# Altium schematic sheet usb-debug.SchDoc(usb-debug)
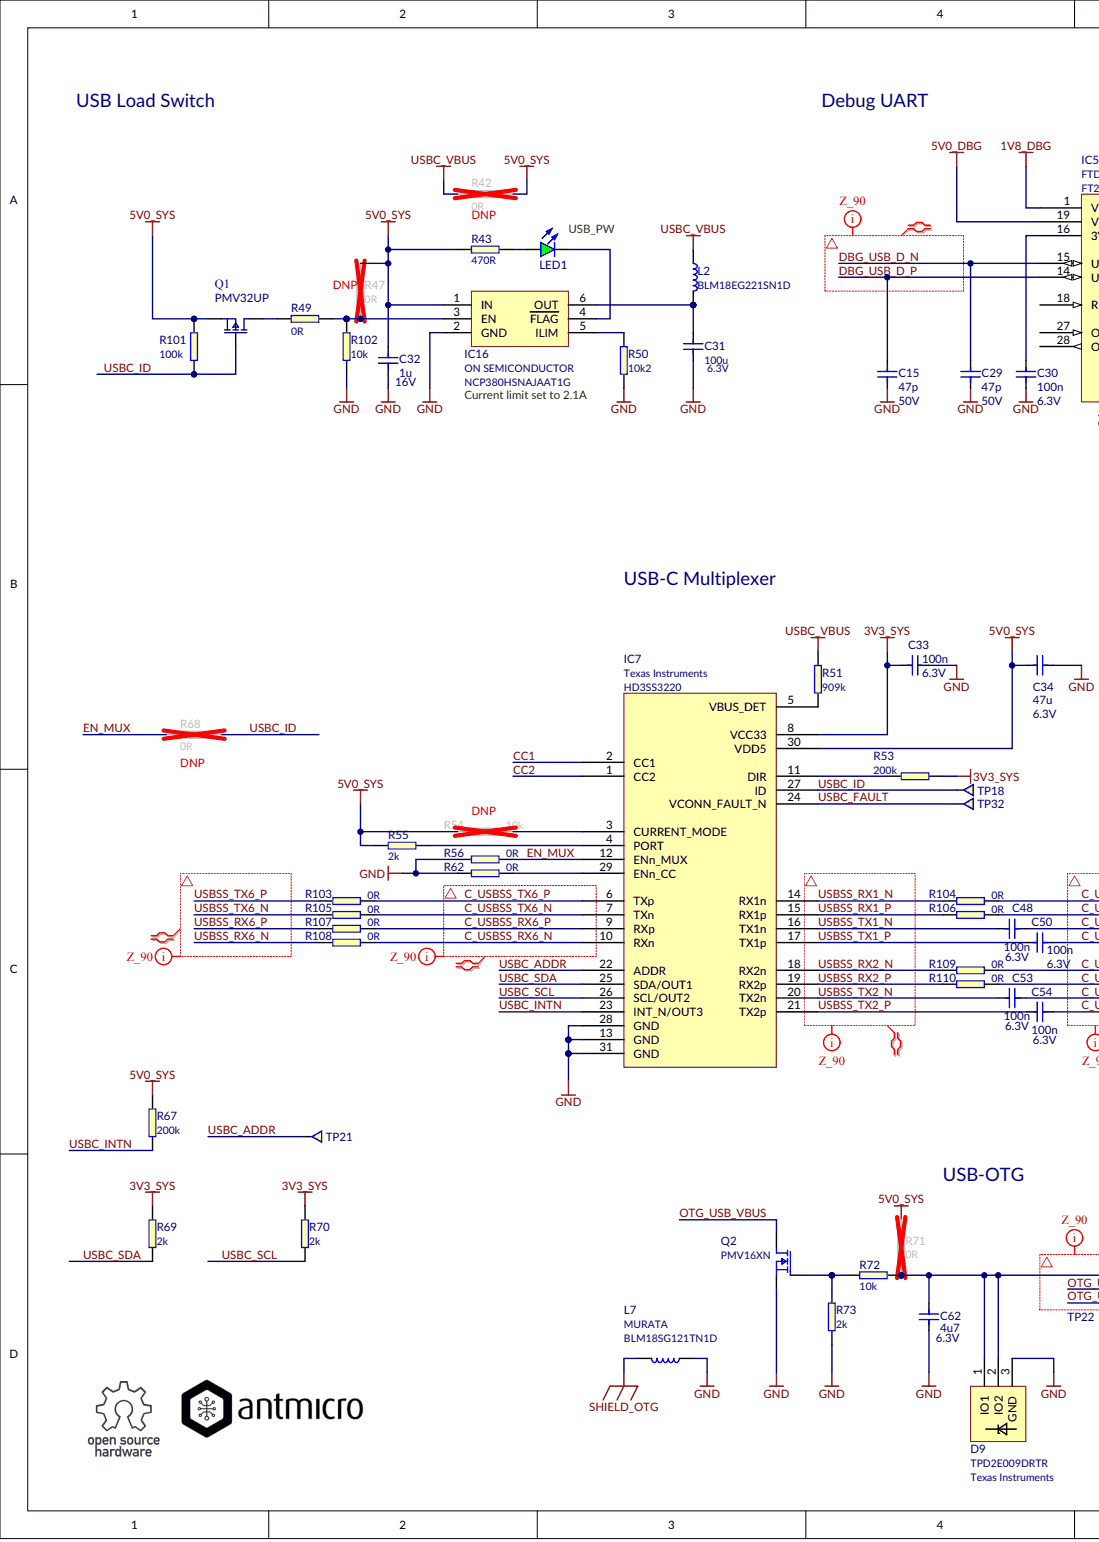
[diagram p0: usb-debug.SchDoc, left]
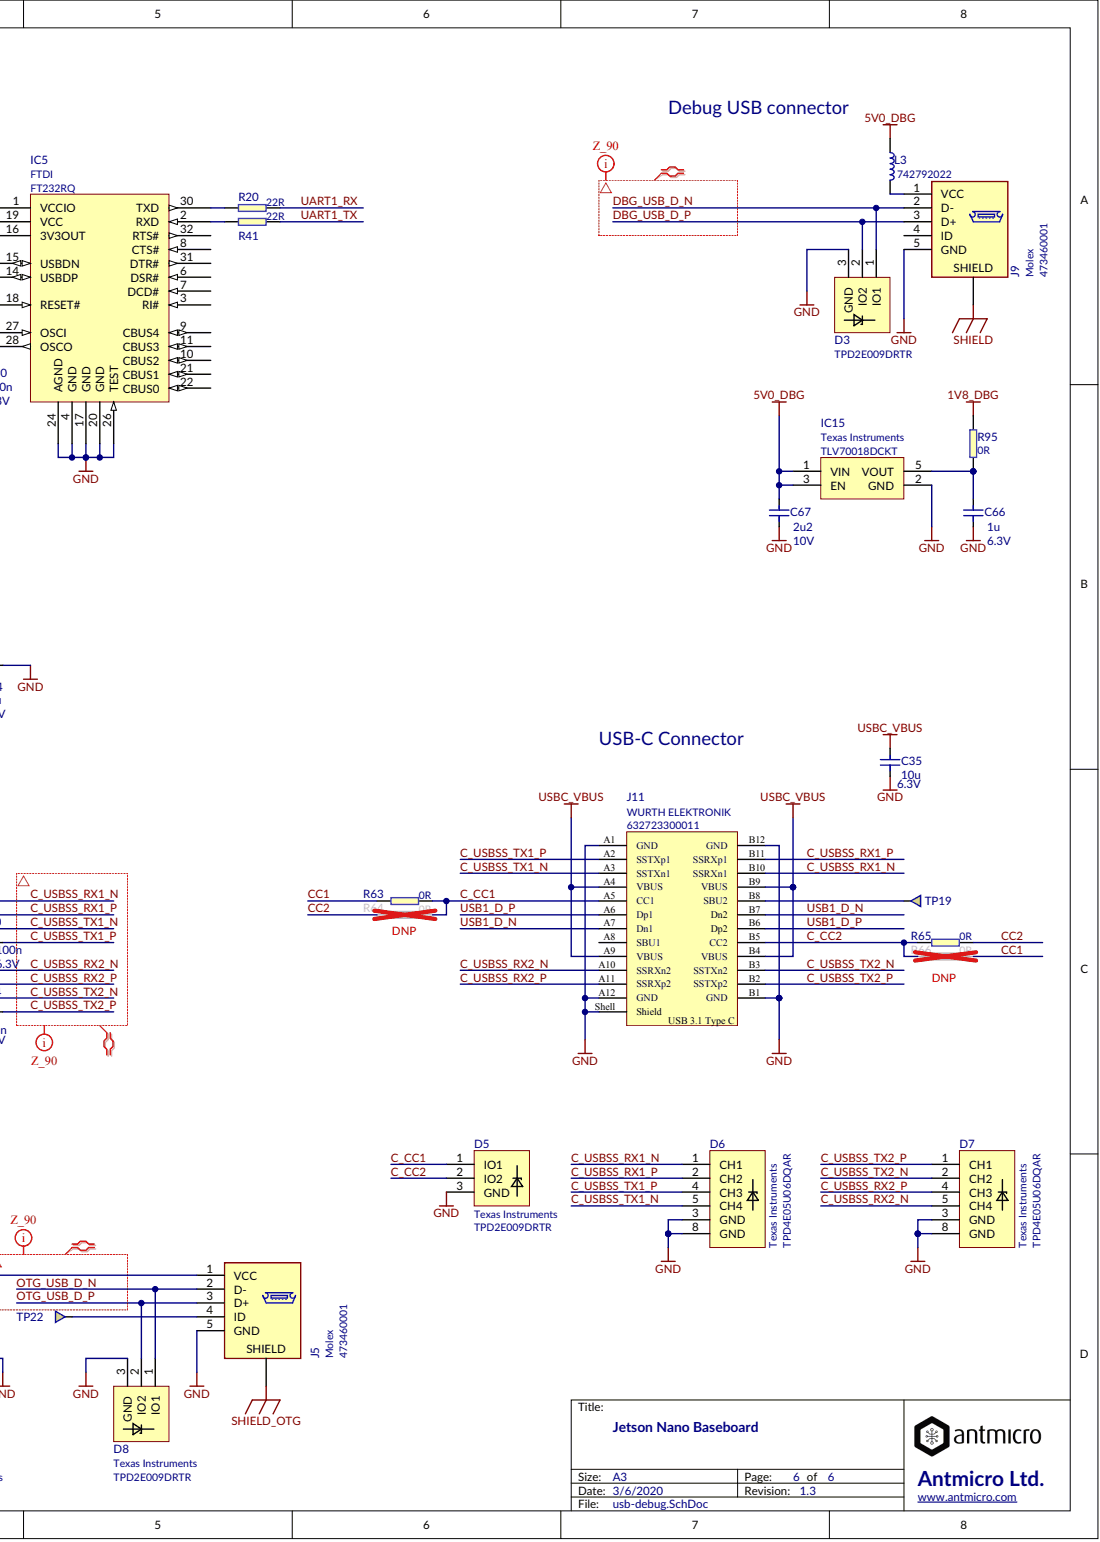
[diagram p1: usb-debug.SchDoc, right]

## Parts
C15  Voltage: 50V  (hidden comment: 47p)
C29  Voltage: 50V  (hidden comment: 47p)
C30  100n
C31  Voltage: 6.3V  (hidden comment: 100u)
C32  Voltage: 16V  (hidden comment: 1u)
C33  Voltage: 6.3V  (hidden comment: 100n)
C34  Voltage: 6.3V  (hidden comment: 47u)
C35  Voltage: 6.3V  (hidden comment: 10u)
C48  100n  (value not drawn)
C50  Voltage: 6.3V  (hidden comment: 100n)
C53  100n
C54  Voltage: 6.3V  (hidden comment: 100n)
C62  Voltage: 6.3V  (hidden comment: 4u7)
C66  Voltage: 6.3V  (hidden comment: 1u)
C67  Voltage: 10V  (hidden comment: 2u2)
D3  TPD2E009DRTR
D5  Manufacturer: Texas Instruments  (hidden comment: TPD2E009DRTR)
D6  Manufacturer: Texas Instruments  PartSymbol: TPD4E05U06DQAR  (hidden comment: TPD4E05U06)
D7  Manufacturer: Texas Instruments  PartSymbol: TPD4E05U06DQAR  (hidden comment: TPD4E05U06)
D8  Manufacturer: Texas Instruments  (hidden comment: TPD2E009DRTR)
D9  TPD2E009DRTR
IC5  Manufacturer: FTDI  (hidden comment: FT232RQ)
IC7  Manufacturer: Texas Instruments  (hidden comment: HD3SS3220)
IC15  Manufacturer: Texas Instruments  (hidden comment: TLV70018DCKT)
IC16  Manufacturer: ON SEMICONDUCTOR  (hidden comment: NCP380HSNAJAAT1G)
J5  Manufacturer: Molex  (hidden comment: Molex 47346-0001)
J9  Manufacturer: Molex  PartSymbol: 473460001  (hidden comment: Molex 47346-0001)
J11  Manufacturer: WURTH ELEKTRONIK  (hidden comment: 632723300011)
L2  BLM18EG221SN1D
L3  742792022
L7  Manufacturer: MURATA  (hidden comment: BLM18SG121TN1D)
LED1  KPT-1608SGC  (value not drawn)
Q1  PMV32UP
Q2  PMV16XN
R20  22R
R41  22R
R42  0R
R43  470R
R47  0R
R49  0R
R50  10k2
R51  909k
R53  200k
R54  10k
R55  2k
R56  0R
R62  0R
R63  0R
R64  0R
R65  0R
R66  0R
R67  200k
R68  0R
R69  2k
R70  2k
R71  0R
R72  10k
R73  2k
R95  0R
R101  100k
R102  10k
R103  0R
R104  0R
R105  0R
R106  0R
R107  0R
R108  0R
R109  0R
R110  0R
TP18  Testpad  (value not drawn)
TP19  Testpad  (value not drawn)
TP21  Testpad  (value not drawn)
TP22  Testpad  (value not drawn)
TP32  Testpad  (value not drawn)

## Nets
1V8_DBG: IC5.1(VCCIO) R95.2
3V3_SYS: C33.1 IC7.8(VCC33) R53.2 R69.1 R70.1
5V0_DBG: C67.2 IC5.19(VCC) IC15.1(VIN) IC15.3(EN) L3.2
5V0_SYS: C32.2 C34.2 IC7.30(VDD5) IC16.1(IN) Q1.2 R42.2 R43.2 R47.2 R54.1 R55.1 R67.2 R71.2 R101.1
GND: C15.1 C29.1 C30.1 C31.1 C32.1 C33.2 C34.1 C35.1 C62.1 C66.1 C67.1 D3.3 D5.3 D6.3(GND) D6.8(GND) D7.3(GND) D7.8(GND) D8.3 D9.3 IC5.4(GND) IC5.17(GND) IC5.20(GND) IC5.24(AGND) IC5.26(TEST) IC7.13(GND) IC7.28(GND) IC7.31(GND) IC15.2(GND) IC16.2(GND) J5.5(GND) J9.5(GND) J11.A1(GND) J11.A12(GND) J11.B1(GND) J11.B12(GND) J11.Shell(Shield) L7.2 Q2.2 R50.1 R56.1 R62.1 R73.2 R102.1
SHIELD: J9.S
SHIELD_OTG: J5.S L7.1
USBC_VBUS: C35.2 J11.A4(VBUS) J11.A9(VBUS) J11.B4(VBUS) J11.B9(VBUS) L2.2 R42.1 R51.2
C_CC1: D5.1 J11.A5(CC1) R63.2 R64.2
C_CC2: D5.2 J11.B5(CC2) R65.1 R66.1
C_USBSS_RX1_N: D6.1(CH1) J11.B10(SSRXn1) R104.2
C_USBSS_RX1_P: D6.2(CH2) J11.B11(SSRXp1) R106.2
C_USBSS_RX2_N: D7.5(CH4) J11.A10(SSRXn2) R109.2
C_USBSS_RX2_P: D7.4(CH3) J11.A11(SSRXp2) R110.2
C_USBSS_RX6_N: IC7.10(RXn) R108.2
C_USBSS_RX6_P: IC7.9(RXp) R107.2
C_USBSS_TX1_N: C48.1 D6.5(CH4) J11.A3(SSTXn1)
C_USBSS_TX1_P: C50.1 D6.4(CH3) J11.A2(SSTXp1)
C_USBSS_TX2_N: C53.1 D7.2(CH2) J11.B3(SSTXn2)
C_USBSS_TX2_P: C54.1 D7.1(CH1) J11.B2(SSTXp2)
C_USBSS_TX6_N: IC7.7(TXn) R105.2
C_USBSS_TX6_P: IC7.6(TXp) R103.2
CC1: IC7.2(CC1) R63.1 R66.2
CC2: IC7.1(CC2) R64.1 R65.2
DBG_USB_D_N: C29.2 D3.1 IC5.15(USBDN) J9.2(D-)
DBG_USB_D_P: C15.2 D3.2 IC5.14(USBDP) J9.3(D+)
EN_MUX: IC7.12(ENn_MUX) R56.2 R68.1
OTG_USB_D_N: D8.1 J5.2(D-)
OTG_USB_D_P: D8.2 J5.3(D+)
OTG_USB_VBUS: Q2.3
UART1_RX: R20.2
UART1_TX: R41.2
USB1_D_N: J11.A7(Dn1) J11.B7(Dn2)
USB1_D_P: J11.A6(Dp1) J11.B6(Dp2)
USBC_ADDR: IC7.22(ADDR) TP21.1
USBC_FAULT: IC7.24(VCONN_FAULT_N) TP32.1
USBC_ID: IC7.27(ID) Q1.1 R68.2 R101.2 TP18.1
USBC_INTN: IC7.23(INT_N/OUT3) R67.1
USBC_SCL: IC7.26(SCL/OUT2) R70.2
USBC_SDA: IC7.25(SDA/OUT1) R69.2
USBSS_RX1_N: IC7.14(RX1n) R104.1
USBSS_RX1_P: IC7.15(RX1p) R106.1
USBSS_RX2_N: IC7.18(RX2n) R109.1
USBSS_RX2_P: IC7.19(RX2p) R110.1
USBSS_RX6_N: R108.1
USBSS_RX6_P: R107.1
USBSS_TX1_N: C48.2 IC7.16(TX1n)
USBSS_TX1_P: C50.2 IC7.17(TX1p)
USBSS_TX2_N: C53.2 IC7.20(TX2n)
USBSS_TX2_P: C54.2 IC7.21(TX2p)
USBSS_TX6_N: R105.1
USBSS_TX6_P: R103.1
NetC30_2: C30.2 IC5.16(3V3OUT)
NetC31_2: C31.2 IC16.6(OUT) L2.1
NetC62_2: C62.2 D9.1 D9.2 J5.1(VCC) R71.1 R72.1
NetC66_2: C66.2 IC15.5(VOUT) R95.1
NetIC5_2: IC5.2(RXD) R41.1
NetIC5_30: IC5.30(TXD) R20.1
NetIC7_3: IC7.3(CURRENT_MODE) R54.2
NetIC7_4: IC7.4(PORT) R55.2
NetIC7_5: IC7.5(VBUS_DET) R51.1
NetIC7_11: IC7.11(DIR) R53.1
NetIC7_29: IC7.29(ENn_CC) R62.2
NetIC16_3: IC16.3(EN) R47.1 R49.2 R102.2
NetIC16_4: IC16.4(FLAG) LED1.K
NetIC16_5: IC16.5(ILIM) R50.2
NetJ5_4: J5.4(ID) TP22.1
NetJ9_1: J9.1(VCC) L3.1
NetJ11_B8: J11.B8(SBU2) TP19.1
NetLED1_A: LED1.A R43.1
NetQ1_3: Q1.3 R49.1
NetQ2_1: Q2.1 R72.2 R73.1
N00534:
N00535:
N00536:
N00537:
N00538:
N00539:
N00540:
N00541:
N00542:
N00543:
N00544:
N00545:
N00546:
N00547:

Not connected: IC5 3(RI#) 6(DSR#) 7(DCD#) 8(CTS#) 9(CBUS4) 10(CBUS2) 11(CBUS3) 18(RESET#) 21(CBUS1) 22(CBUS0) 27(OSCI) 28(OSCO) 31(DTR#) 32(RTS#); J9 4(ID); J11 A8(SBU1)

## Library data of the parts
C15 C29 = (no symbol name): PartNumber: C_47p_0402; Manufacturer: KEMET; PartSymbol: C0402C470J5GACTU; Value: 47p
C30 = (no symbol name): Manufacturer: TDK; PartNumber: C_100n_0402; PartSymbol: C1005X5R0J104K050BA; Voltage: 6.3V; Value: 100n
C31 = (no symbol name): PartNumber: C_100u_1206; Manufacturer: VISHAY; PartSymbol: TMCMA0J107MTRF; Value: 100u
C32 = (no symbol name): Manufacturer: KEMET; PartNumber: C_1u_0603; PartSymbol: C0603C105M4PACTU; Value: 1u
C33 = (no symbol name): Manufacturer: TDK; PartNumber: C_100n_0402; PartSymbol: C1005X5R0J104K050BA; Value: 100n
C34 = (no symbol name): PartNumber: C_47u_0805; Manufacturer: KEMET; PartSymbol: C0805C476M9PACTU; Value: 47u
C35 = (no symbol name): PartNumber: C_10u_0603; Manufacturer: Walsin; PartSymbol: 0603X106M6R3CT; Value: 10u
C48 C53 = (no symbol name): Manufacturer: TDK; PartNumber: C_100n_0402; PartSymbol: C1005X5R0J104K050BA; Voltage: 6.3V; Voltage: 6.3; Value: 100n
C50 C54 = (no symbol name): Manufacturer: TDK; PartNumber: C_100n_0402; PartSymbol: C1005X5R0J104K050BA; Voltage: 6.3; Value: 100n
C62 = (no symbol name): PartNumber: C_4u7_0603; Manufacturer: MULTICOMP; PartSymbol: MC0603X475K6R3CT; Value: 4u7
C66 = (no symbol name): Manufacturer: MULTICOMP; PartNumber: C_1u_0402; PartSymbol: MC0402F105Z6R3CT; Value: 1u
C67 = (no symbol name): Manufacturer: TDK; PartNumber: C_2u2_0402; PartSymbol: C1005X5R1A225K050BC; Value: 2u2
D3 D9 = (no symbol name): Manufacturer: Texas Instruments; Value: TPD2E009DRTR; PartNumber: TPD2E009DRTR; PartSymbol: TPD2E009DRTR
D6 D7 = (no symbol name): PartNumber: TPD4E05U06_PASS; Value: TPD4E05U06
IC5 = (no symbol name): PartSymbol: FT232RQ-REEL; Value: FT232RQ; PartNumber: FT232RQ
IC7 = (no symbol name): PartSymbol: HD3SS3220RNHT; PartNumber: HD3SS3220; Value: HD3SS3220
J5 = (no symbol name): PartNumber: CON-Molex-47346-0001; PartSymbol: 473460001; Value: Molex 47346-0001
J9 = (no symbol name): PartNumber: CON-Molex-47346-0001; Value: Molex 47346-0001
J11 = (no symbol name): PartNumber: WE_632723300011; Value: 632723300011; PartSymbol: 632723300011
L2 = (no symbol name): Manufacturer: MURATA; Value: BLM18EG221SN1D; PartNumber: BLM18EG221SN1D; PartSymbol: BLM18EG221SN1D
L3 = (no symbol name): Manufacturer: WURTH ELEKTRONIK; Value: 742792022; PartNumber: 742792022; PartSymbol: 742792022
LED1 = (no symbol name): Manufacturer: KINGBRIGHT; PartNumber: LED_G; Value: KPT-1608SGC; PartSymbol: KPT-1608SGC
Q1 Q2 = (no symbol name): Manufacturer: NXP
  Q1: PartNumber: PMV32UP; Value: PMV32UP; PartSymbol: PMV32UP
  Q2: Value: PMV16XN; PartNumber: PMV16XN; PartSymbol: PMV16XN
R20 R41 = (no symbol name): Manufacturer: VISHAY; PartNumber: R_22R_0402; PartSymbol: CRCW040222R0FKED; Value: 22R
R42 = (no symbol name): Manufacturer: BOURNS; PartNumber: R_0R_0603; PartSymbol: CR0603-J/-000ELF; Value: 0R
R43 = (no symbol name): PartNumber: R_470R_0402; Manufacturer: VISHAY; PartSymbol: CRCW0402470RFKED.; Value: 470R
R47 R49 R56 R62-R66 R68 R71 R103-R110 = (no symbol name): PartNumber: R_0R_0402; Manufacturer: Panasonic; PartSymbol: ERJ2GE0R00X; Value: 0R
R50 = (no symbol name): PartNumber: R_5k6_0603; Manufacturer: Panasonic; PartSymbol: ERJ3GEYJ562V; Value: 10k2
R51 = (no symbol name): PartNumber: R_909k_0402; Manufacturer: Panasonic; PartSymbol: ERJ2RKF9093X; Value: 909k
R53 R67 = (no symbol name): PartNumber: R_200k_0402; Manufacturer: YAGEO; PartSymbol: RC0402FR-07200KL; Value: 200k
R54 R72 = (no symbol name): PartNumber: R_10k_0402; Manufacturer: MULTICOMP; PartSymbol: MCMR04X1002FTL; Value: 10k
R55 R69 R70 R73 = (no symbol name): PartNumber: R_2k_0402; Manufacturer: VISHAY; PartSymbol: CRCW04022K00FKED; Value: 2k
R95 = (no symbol name): Manufacturer: Panasonic; PartNumber: R_0R_0402; PartSymbol: ERJ2GE0R00X; Value: 0R
R101 = (no symbol name): Manufacturer: BOURNS; PartNumber: R_100k_0603; PartSymbol: CR0603-FX-1003HLF; Value: 100k
R102 = (no symbol name): Manufacturer: MULTICOMP; PartNumber: R_10k_0402; PartSymbol: MCMR04X1002FTL; Value: 10k
TP18 TP19 TP21 TP22 TP32 = (no symbol name): PartNumber: TP-R-1.5
D5 D8 IC15 IC16 L7 = (no symbol name)
  D5: PartNumber: TPD2E009DRTR; Value: TPD2E009DRTR; PartSymbol: TPD2E009DRTR
  D8: Value: TPD2E009DRTR; PartNumber: TPD2E009DRTR; PartSymbol: TPD2E009DRTR
  IC15: Value: TLV70018DCKT; PartNumber: TLV70018DCKT; PartSymbol: TLV70018DCKT
  IC16: Value: NCP380HSNAJAAT1G; PartNumber: NCP380HSNAJAAT1G; PartSymbol: NCP380HSNAJAAT1G
  L7: Value: BLM18SG121TN1D; PartNumber: BLM18SG121TN1D; PartSymbol: BLM18SG121TN1D
